# Altium schematic sheet Sheet1.SchDoc
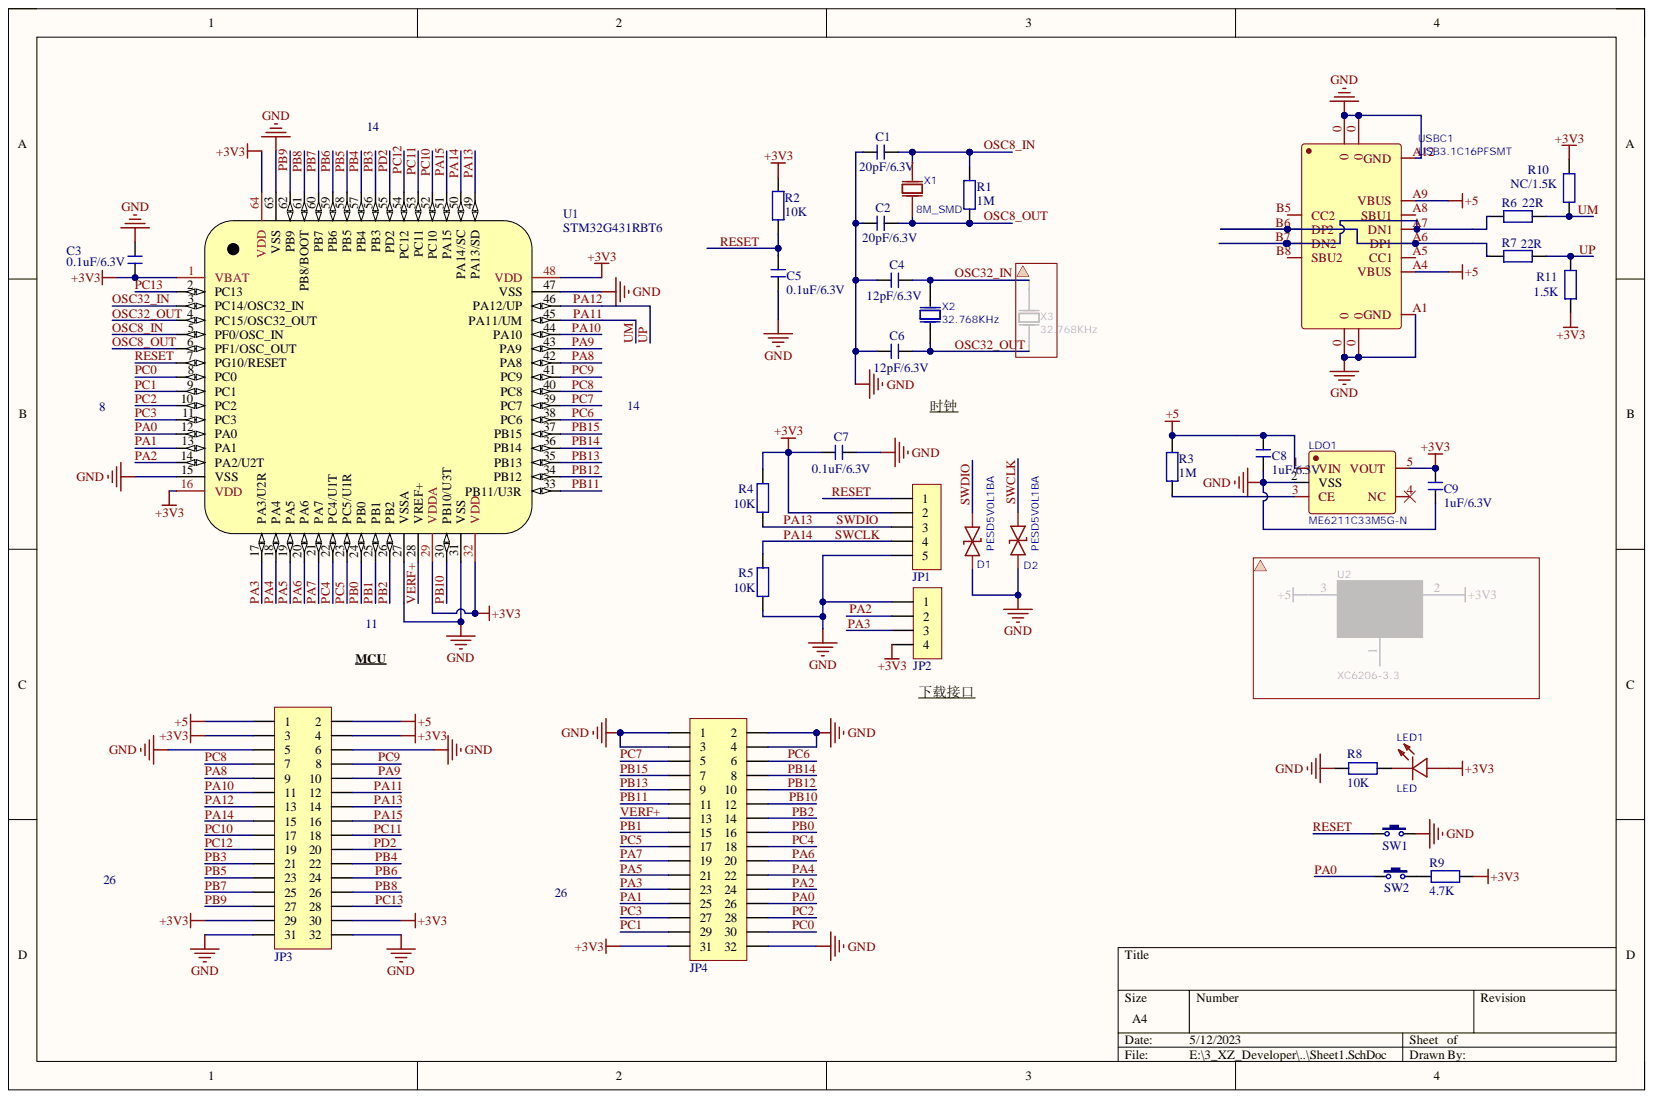
[diagram p0: Sheet1.SchDoc]

## Parts
C1  20pF/6.3V  [C 0603_L]
C2  20pF/6.3V  [C 0603_L]
C3  0.1uF/6.3V  [C 0603_L]
C4  12pF/6.3V  [C 0603_L]
C5  0.1uF/6.3V  [C 0603_L]
C6  12pF/6.3V  [C 0603_L]
C7  0.1uF/6.3V  [C 0603_L]
C8  1uF/6.3V  [C 0603_L]
C9  1uF/6.3V  [C 0603_L]
D1  PESD5V0L1BA  [SOD-323_L1.8-W1.3-LS2.5-BI]
D2  PESD5V0L1BA  [SOD-323_L1.8-W1.3-LS2.5-BI]
JP1  HDR-1X5  [HDR2.54-LI-5P]  (value not drawn)
JP2  HDR-1X4  [HDR2.54-LI-4P]  (value not drawn)
JP3  Header 2X11  [HDR2.54-LI-2x16P]  (value not drawn)
JP4  Header 2X11  [HDR2.54-LI-2x16P]  (value not drawn)
LDO1  ME6211C33M5G-N  [SOT-23-5_L3.0-W1.7-P0.95-LS2.8-BR]
LED1  LED  [LED0603-RD]
R1  1M  [R 0603_L]
R2  10K  [R 0603_L]
R3  1M  [R 0603_L]
R4  10K  [R 0603_L]
R5  10K  [R 0603_L]
R6  22R  [R 0603_L]
R7  22R  [R 0603_L]
R8  10K  [R 0603_L]
R9  4.7K  [R 0603_L]
R10  NC/1.5K  [R 0603_L]  (no BOM)
R11  1.5K  [R 0603_L]
SW1  3x6x5  [TSW SMD-3*6*2.5]  (value not drawn)
SW2  3x6x5  [TSW SMD-3*6*2.5]  (value not drawn)
U1  STM32G431RBT6  [LQFP64-10*10]
USBC1  USB3.1C16PFSMT  [USB-C-SMD_TYPE-C-USB-17]
X1  8M_SMD  [HC-49S_L11.4-W4.8]
X2  32.768KHz  [OSC-SMD_L3.2-W1.5]

## Nets
+3V3: C3.2 C7.2 C9.2 JP1.2 JP2.4 JP3.3 JP3.4 JP3.29 JP3.30 JP4.31 LDO1.5(VOUT) LED1.2 R2.1 R4.1 R9.2 R10.1 R11.2 U1.1(VBAT) U1.16(VDD) U1.29(VDDA) U1.32(VDD) U1.48(VDD) U1.64(VDD)
+5: C8.2 JP3.1 JP3.2 LDO1.1(VIN) R3.2 USBC1.A4(VBUS) USBC1.A9(VBUS)
GND: C1.2 C2.2 C3.1 C4.2 C5.1 C6.2 C7.1 C8.1 C9.1 D1.1 D2.1 JP1.5 JP2.1 JP3.5 JP3.6 JP3.31 JP3.32 JP4.1 JP4.2 JP4.3 JP4.4 JP4.32 LDO1.2(VSS) R5.2 R8.1 SW1.2 U1.15(VSS) U1.27(VSSA) U1.31(VSS) U1.47(VSS) U1.63(VSS) USBC1.0 USBC1.A1(GND) USBC1.A12(GND)
OSC8_IN: C1.1 R1.1 U1.5(PF0/OSC_IN) X1.2
OSC8_OUT: C2.1 R1.2 U1.6(PF1/OSC_OUT) X1.1
OSC32_IN: C4.1 U1.3(PC14/OSC32_IN) X2.2
OSC32_OUT: C6.1 U1.4(PC15/OSC32_OUT) X2.1
PA0: JP4.26 SW2.1 U1.12(PA0)
PA1: JP4.25 U1.13(PA1)
PA2: JP2.2 JP4.24 U1.14(PA2/U2T)
PA3: JP2.3 JP4.23 U1.17(PA3/U2R)
PA4: JP4.22 U1.18(PA4)
PA5: JP4.21 U1.19(PA5)
PA6: JP4.20 U1.20(PA6)
PA7: JP4.19 U1.21(PA7)
PA8: JP3.9 U1.42(PA8)
PA9: JP3.10 U1.43(PA9)
PA10: JP3.11 U1.44(PA10)
PA11: JP3.12 R6.2 R10.2 U1.45(PA11/UM)
PA12: JP3.13 R7.2 R11.1 U1.46(PA12/UP)
PA13: D1.2 JP1.3 JP3.14 R4.2 U1.49(PA13/SD)
PA14: D2.2 JP1.4 JP3.15 R5.1 U1.50(PA14/SC)
PA15: JP3.16 U1.51(PA15)
PB0: JP4.16 U1.24(PB0)
PB1: JP4.15 U1.25(PB1)
PB2: JP4.14 U1.26(PB2)
PB3: JP3.21 U1.56(PB3)
PB4: JP3.22 U1.57(PB4)
PB5: JP3.23 U1.58(PB5)
PB6: JP3.24 U1.59(PB6)
PB7: JP3.25 U1.60(PB7)
PB8: JP3.26 U1.61(PB8/BOOT)
PB9: JP3.27 U1.62(PB9)
PB10: JP4.12 U1.30(PB10/U3T)
PB11: JP4.11 U1.33(PB11/U3R)
PB12: JP4.10 U1.34(PB12)
PB13: JP4.9 U1.35(PB13)
PB14: JP4.8 U1.36(PB14)
PB15: JP4.7 U1.37(PB15)
PC0: JP4.30 U1.8(PC0)
PC1: JP4.29 U1.9(PC1)
PC2: JP4.28 U1.10(PC2)
PC3: JP4.27 U1.11(PC3)
PC4: JP4.18 U1.22(PC4/U1T)
PC5: JP4.17 U1.23(PC5/U1R)
PC6: JP4.6 U1.38(PC6)
PC7: JP4.5 U1.39(PC7)
PC8: JP3.7 U1.40(PC8)
PC9: JP3.8 U1.41(PC9)
PC10: JP3.17 U1.52(PC10)
PC11: JP3.18 U1.53(PC11)
PC12: JP3.19 U1.54(PC12)
PC13: JP3.28 U1.2(PC13)
PD2: JP3.20 U1.55(PD2)
RESET: C5.2 JP1.1 R2.2 SW1.1 U1.7(PG10/RESET)
VERF+: JP4.13 U1.28(VREF+)
NetLDO1_3: LDO1.3(CE) R3.1
NetLED1_1: LED1.1 R8.2
NetR6_1: R6.1 USBC1.A7(DN1) USBC1.B7(DN2)
NetR7_1: R7.1 USBC1.A6(DP1) USBC1.B6(DP2)
NetR9_1: R9.1 SW2.2

Not connected: LDO1 4(NC); USBC1 A5(CC1) A8(SBU1) B5(CC2) B8(SBU2)

## Library data of the parts
C1-C9 = C: 贴片电容; BOM名称: 无极性贴片电容; 淘宝ID: 封装库来自淘宝 ID：黄晶宝石; Component Kind: Standard; Library Name: 0.1 - 电阻-电容-电感.SchLib; 唯一出售店铺网址: https://shop463601444.taobao.com; 联系售后，技术支持: https://shop463601444.taobao.com
JP1 = Header 5: 5P接插件; 版权归属: 封装库来自淘宝 ID：黄晶宝石; BOM名称: 5P接插件; Component Kind: Standard; Library Name: 5.3 - 接插件-脚距2.54 (100mil) .SchLib; 学习资源: https://shop463601444.taobao.com
JP2 = Header 4: 4P接插件; 版权归属: 封装库来自淘宝 ID：黄晶宝石; BOM名称: 4P接插件; Component Kind: Standard; Library Name: 5.3 - 接插件-脚距2.54 (100mil) .SchLib; 学习资源: https://shop463601444.taobao.com
JP3 JP4 = Header 16X2: 16*2P接插件; 版权归属: 封装库来自淘宝卖家 ID：黄晶宝石; BOM名称: 16*2P接插件; Component Kind: Standard; Library Name: 5.3 - 接插件-脚距2.54 (100mil) .SchLib; 学习资源: https://shop463601444.taobao.com
R1-R11 = R: 贴片电阻; 封装库来自淘宝卖家 ID：黄晶宝石: 其他店铺购入均为盗版，不享受售后技术支持服务，请立即申请退款; 版权归属: 来自淘宝 ID：黄晶宝石; BOM名称: 贴片电阻; Library Name: 0.1 - 电阻-电容-电感.SchLib; 联系售后: https://shop463601444.taobao.com
  R1-R9 R11: Component Kind: Standard
SW1 SW2 = TSW 3x6: 3x6轻触开关; 版权归属: 封装库来自淘宝 ID：黄晶宝石; BOM名称: 3x6轻触开关; Component Kind: Standard; Library Name: 0.7 - 常用按键-开关.SchLib; 学习资源: https://shop463601444.taobao.com
D1 D2 = PESD5V0L1BA: Component Kind: Standard; Library Name: 7.2-常用开发库.IntLib
LDO1 = ME6211C33M5G-N: Component Kind: Standard; Library Name: 7.2-常用开发库.IntLib
LED1 = LED: Component Kind: Standard; Library Name: 7.2-常用开发库.IntLib
U1 = STM32G431RBT6: Component Kind: Standard; Library Name: STM32G4 系列单片机.SchLib
USBC1 = USB3.1C16PFSMT: Component Kind: Standard; Library Name: 7.2-常用开发库.IntLib
X1 = 8M_SMD: Component Kind: Standard; Library Name: 7.2-常用开发库.IntLib
X2 = 32.768KHz: Component Kind: Standard; Library Name: 7.2-常用开发库.IntLib
